# Altium schematic sheet MCU.SchDoc(MCU)
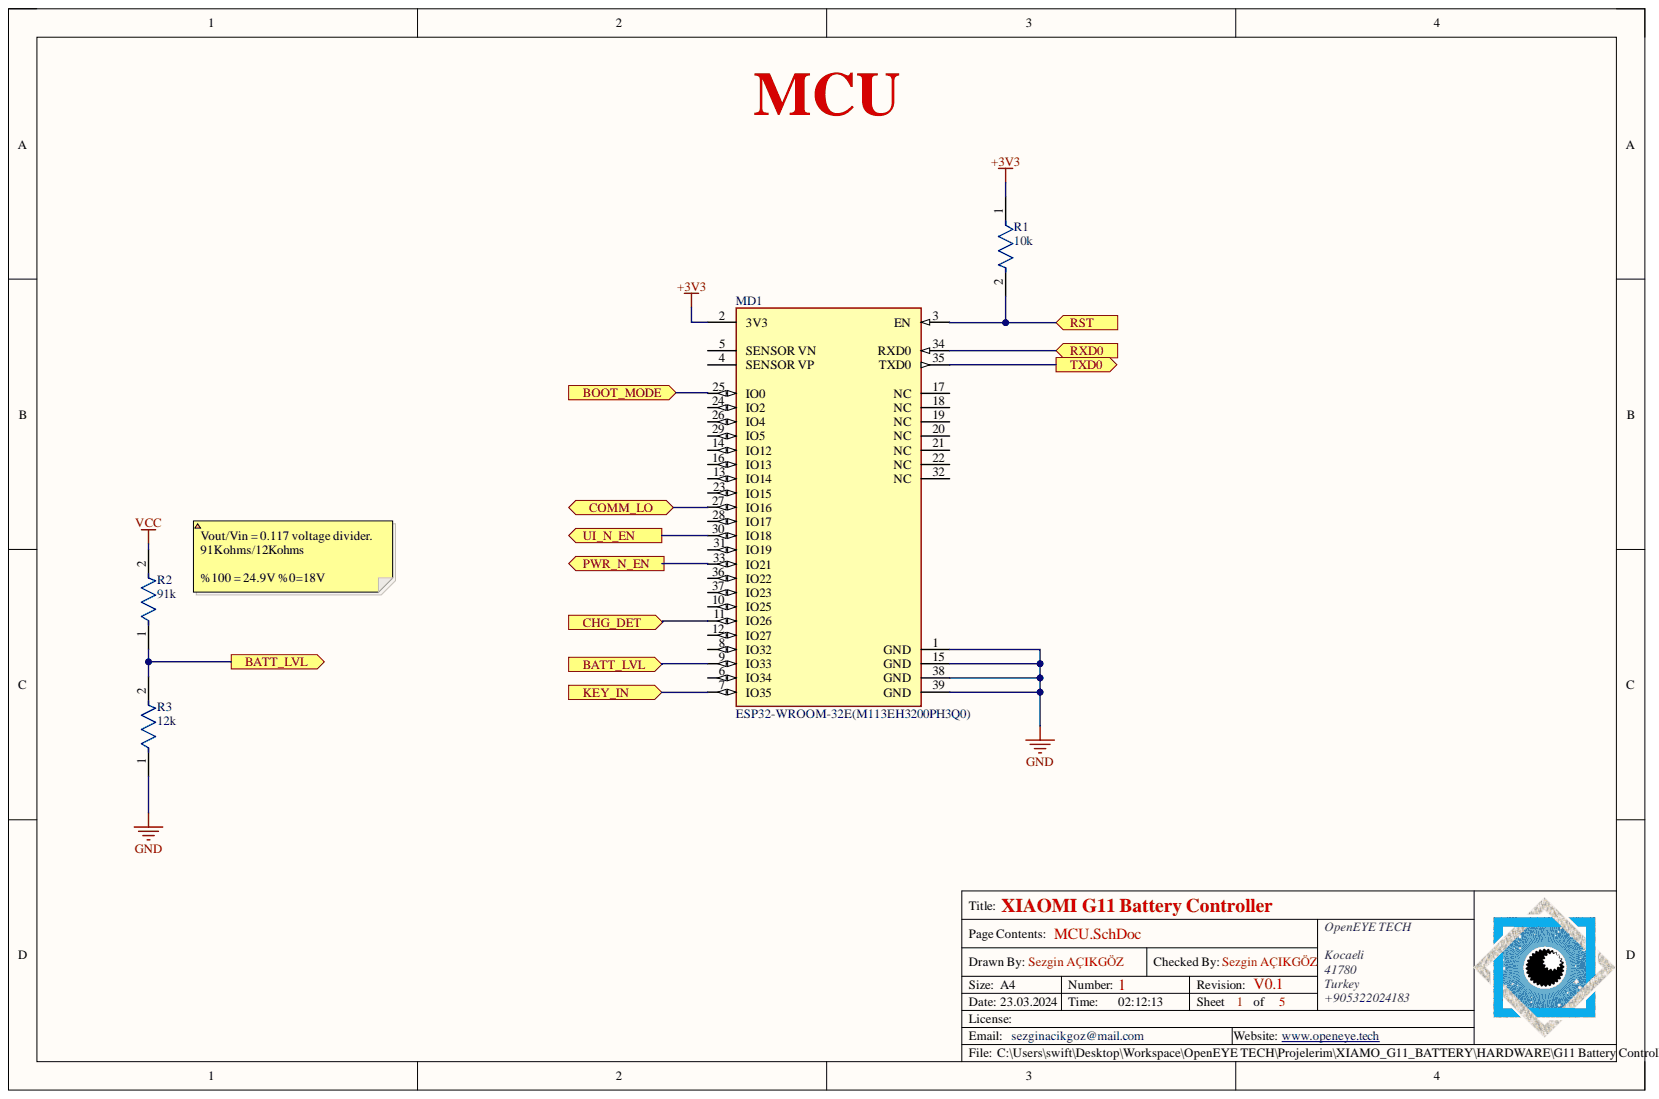
[diagram p0: MCU.SchDoc]

## Parts
MD1  ESP32-WROOM-32E(M113EH3200PH3Q0)  [FP-ESP32-WROOM-32E_M113EH-MFG]
R1  10k  [FP-RP731J-MFG]
R2  91k  [FP-RP731J-MFG]
R3  12k  [FP-RP731J-MFG]

## Ports
BATT_LVL, BOOT_MODE, CHG_DET, COMM_LO, KEY_IN, PWR_N_EN, RST, RXD0, TXD0, UI_N_EN

## Nets
+3V3: MD1.2(3V3) R1.1
GND: MD1.1(GND) MD1.15(GND) MD1.38(GND) MD1.39(GND) R3.1
VCC: R2.2
BATT_LVL: MD1.9(IO33) R2.1 R3.2 ; port BATT_LVL
BOOT_MODE: MD1.25(IO0) ; port BOOT_MODE
CHG_DET: MD1.11(IO26) ; port CHG_DET
COMM_LO: MD1.27(IO16) ; port COMM_LO
KEY_IN: MD1.7(IO35) ; port KEY_IN
PWR_N_EN: MD1.33(IO21) ; port PWR_N_EN
RST: MD1.3(EN) R1.2 ; port RST
RXD0: MD1.34(RXD0) ; port RXD0
TXD0: MD1.35(TXD0) ; port TXD0
UI_N_EN: MD1.30(IO18) ; port UI_N_EN

Not connected: MD1 4(SENSOR_VP) 5(SENSOR_VN) 6(IO34) 8(IO32) 10(IO25) 12(IO27) 13(IO14) 14(IO12) 16(IO13) 17(NC) 18(NC) 19(NC) 20(NC) 21(NC) 22(NC) 23(IO15) 24(IO2) 26(IO4) 28(IO17) 29(IO5) 31(IO19) 32(NC) 36(IO22) 37(IO23)

## Library data of the parts
MD1 = CMP-194065-000012-1: RX TXRX MOD WIFI TRACE ANT SMD; Component Kind: Standard; LCSC Part #: C701341; Library Name: Altium Content Vault
R1 = CMP-03211-008596-1: TE CONNECTIVITY / HOLSWORTHY SMD Chip Resistor, Thin Film, 8.45Ω, 50 V, 0603 [1608 Metric], 100 mW, 0.1% , RP73 Series; Manufacturer Part Number: RP73D1J8R45BTDG; Power Rating: 0.1W; Resistance: 10kΩ; Temperature Coefficient: 15ppm/°C; Value: 10kΩ; Voltage Rating: 75V; Automotive: No; Case/Package: 603; Category: Res; Component Kind: Standard; ComponentLink2Description: Datasheet; ComponentLink2URL: https://www.te.com/commerce/DocumentDelivery/DDEController?Action=srchrtrv&DocNm=1773272&DocType=DS&DocLang=English; Composition: Thin Film; Device Class L1: Passive Components; Device Class L2: Resistors; Device Class L3: Chip SMD Resistors; Height: 0.55mm; Lead Free: Yes; Library Name: Altium Content Vault; Manufacturer: TE Connectivity; Octopart: https://octopart.com/rp73d1j8r45btdg-te+connectivity-42630912; octopart_url: https://octopart.com/rp73d1j8r45btdg-te+connectivity-42630912; Package: RP731J; packaging: cut tape; RoHS: TRUE; Series: RP73; Temperature: -55°C to +105°C; Temperature Range High: +105°C; Temperature Range Low: -55°C; Tolerance: 0.1%; Ipc Land Pattern Name: RESC15580X45
R2 = CMP-03211-008596-1: TE CONNECTIVITY / HOLSWORTHY SMD Chip Resistor, Thin Film, 8.45Ω, 50 V, 0603 [1608 Metric], 100 mW, 0.1% , RP73 Series; Manufacturer Part Number: RP73D1J8R45BTDG; Power Rating: 0.1W; Resistance: 91kΩ; Temperature Coefficient: 15ppm/°C; Value: 91kΩ; Voltage Rating: 75V; Automotive: No; Case/Package: 603; Category: Res; Component Kind: Standard; ComponentLink2Description: Datasheet; ComponentLink2URL: https://www.te.com/commerce/DocumentDelivery/DDEController?Action=srchrtrv&DocNm=1773272&DocType=DS&DocLang=English; Composition: Thin Film; Device Class L1: Passive Components; Device Class L2: Resistors; Device Class L3: Chip SMD Resistors; Height: 0.55mm; Lead Free: Yes; Library Name: Altium Content Vault; Manufacturer: TE Connectivity; Octopart: https://octopart.com/rp73d1j8r45btdg-te+connectivity-42630912; octopart_url: https://octopart.com/rp73d1j8r45btdg-te+connectivity-42630912; Package: 603; packaging: cut tape; RoHS: TRUE; Series: RP73; Temperature: -55°C to +105°C; Temperature Range High: +105°C; Temperature Range Low: -55°C; Tolerance: 0.1%; Ipc Land Pattern Name: RESC15580X45
R3 = CMP-03211-008596-1: TE CONNECTIVITY / HOLSWORTHY SMD Chip Resistor, Thin Film, 8.45Ω, 50 V, 0603 [1608 Metric], 100 mW, 0.1% , RP73 Series; Manufacturer Part Number: RP73D1J8R45BTDG; Power Rating: 0.1W; Resistance: 12kΩ; Temperature Coefficient: 15ppm/°C; Value: 12kΩ; Voltage Rating: 75V; Automotive: No; Case/Package: 603; Category: Res; Component Kind: Standard; ComponentLink2Description: Datasheet; ComponentLink2URL: https://www.te.com/commerce/DocumentDelivery/DDEController?Action=srchrtrv&DocNm=1773272&DocType=DS&DocLang=English; Composition: Thin Film; Device Class L1: Passive Components; Device Class L2: Resistors; Device Class L3: Chip SMD Resistors; Height: 0.55mm; Lead Free: Yes; Library Name: Altium Content Vault; Manufacturer: TE Connectivity; Octopart: https://octopart.com/rp73d1j8r45btdg-te+connectivity-42630912; octopart_url: https://octopart.com/rp73d1j8r45btdg-te+connectivity-42630912; Package: 603; packaging: cut tape; RoHS: TRUE; Series: RP73; Temperature: -55°C to +105°C; Temperature Range High: +105°C; Temperature Range Low: -55°C; Tolerance: 0.1%; Ipc Land Pattern Name: RESC15580X45
